# Altium schematic sheet pca20017_motors.SchDoc
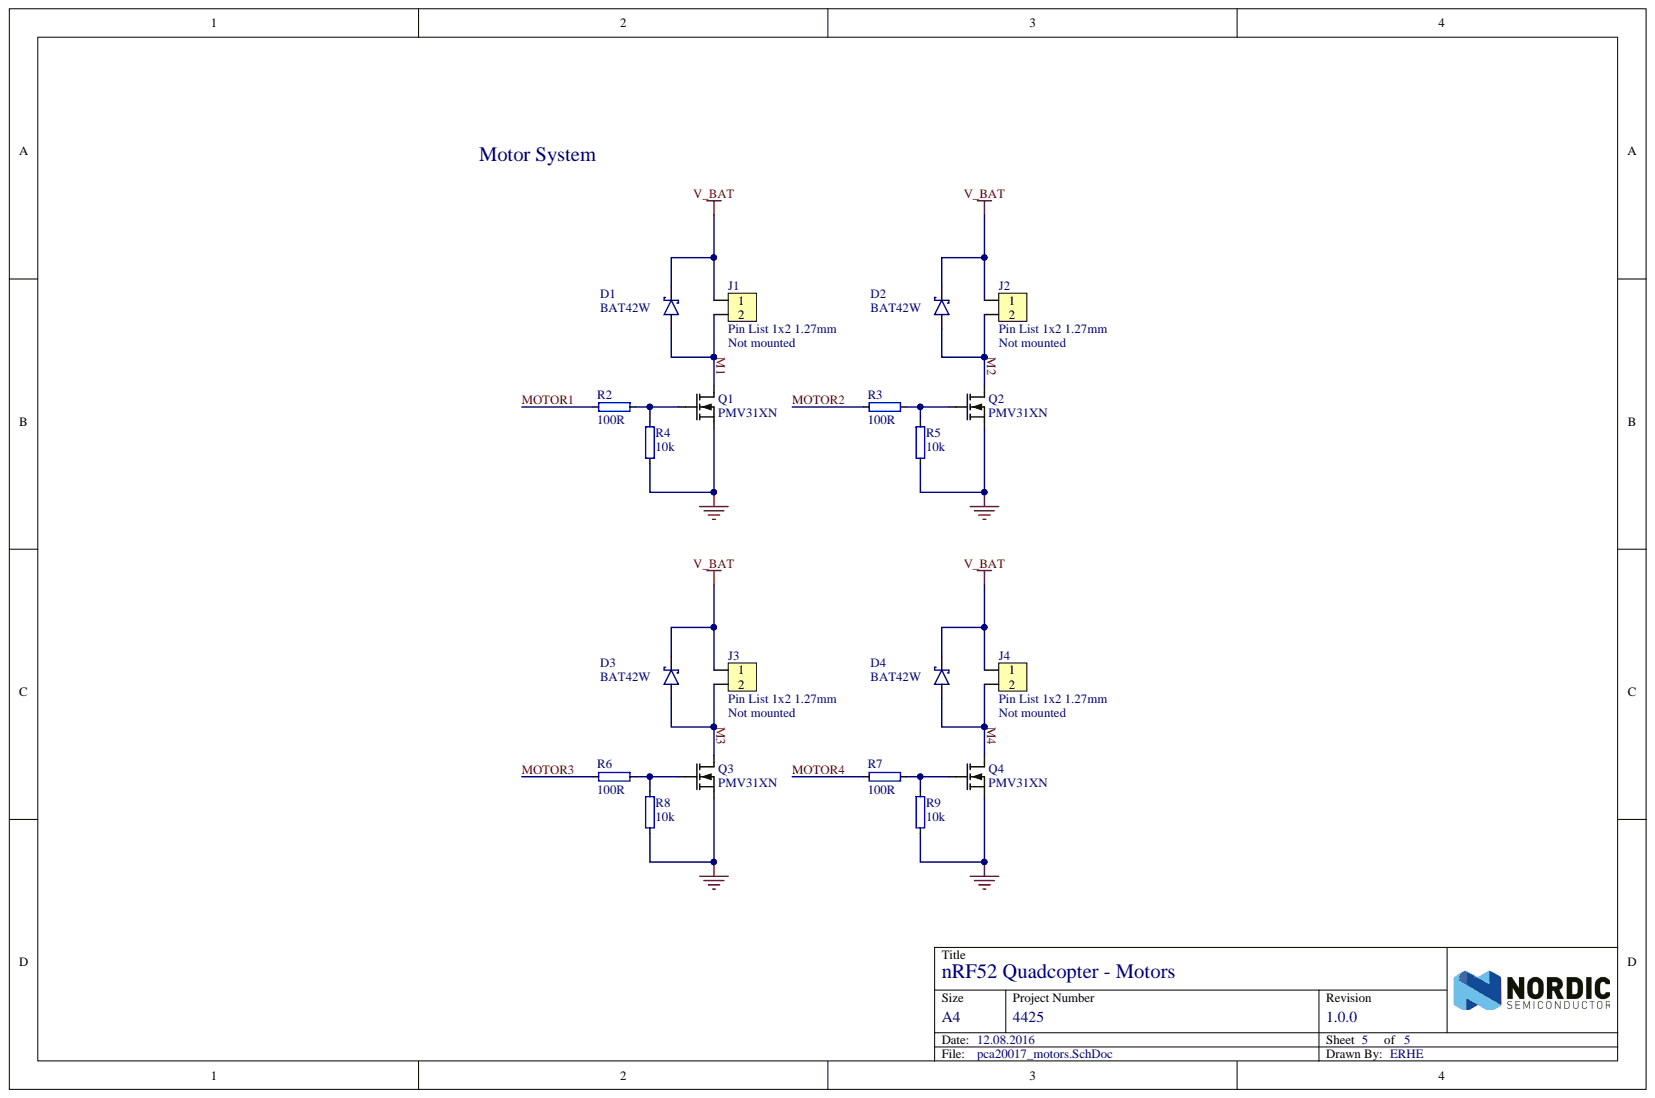
[diagram p0: pca20017_motors.SchDoc]

## Parts
D1  BAT42W  [SOD-123]
D2  BAT42W  [SOD-123]
D3  BAT42W  [SOD-123]
D4  BAT42W  [SOD-123]
J1  Pin List 1x2 1.27mm  Assembly: Not mounted  [LIST_1x2_TH_1.27mm_N.C.]
J2  Pin List 1x2 1.27mm  Assembly: Not mounted  [LIST_1x2_TH_1.27mm_N.C.]
J3  Pin List 1x2 1.27mm  Assembly: Not mounted  [LIST_1x2_TH_1.27mm_N.C.]
J4  Pin List 1x2 1.27mm  Assembly: Not mounted  [LIST_1x2_TH_1.27mm_N.C.]
Q1  PMV31XN  [SOT-23a]
Q2  PMV31XN  [SOT-23a]
Q3  PMV31XN  [SOT-23a]
Q4  PMV31XN  [SOT-23a]
R2  100R  [RESC1005X04L]
R3  100R  [RESC1005X04L]
R4  10k  [RESC1005X04L]
R5  10k  [RESC1005X04L]
R6  100R  [RESC1005X04L]
R7  100R  [RESC1005X04L]
R8  10k  [RESC1005X04L]
R9  10k  [RESC1005X04L]

## Nets
GND: Q1.2 Q2.2 Q3.2 Q4.2 R4.1 R5.1 R8.1 R9.1
V_BAT: D1.3 D2.3 D3.3 D4.3 J1.1 J2.1 J3.1 J4.1
M1: D1.1 J1.2 Q1.3
M2: D2.1 J2.2 Q2.3
M3: D3.1 J3.2 Q3.3
M4: D4.1 J4.2 Q4.3
MOTOR1: R2.1
MOTOR2: R3.1
MOTOR3: R6.1
MOTOR4: R7.1
NetQ1_1: Q1.1 R2.2 R4.2
NetQ2_1: Q2.1 R3.2 R5.2
NetQ3_1: Q3.1 R6.2 R8.2
NetQ4_1: Q4.1 R7.2 R9.2

## Library data of the parts
D1-D4 = BAT42W: Diode Schottky, Vr=30V, If=200mA, Vf=400mV, SOD-123; Manufacturer: Multicomp; Artikkelnr Noca: 10029103; Artikkelnr Simpro: N.A.; Database Table Name: Semiconductors$; Component Kind: Standard; Datasheet: http://www.farnell.com/datasheets/62636.pdf; Footprint Doc: SOD-123; LatestRevisionDate: 2011-10-10; Library Name: Nordic Discrete DB.DbLib; Manufacturer Part Number: BAT42W; Note: Diode; Part Number: BAT42W; Pin Count: 2; Published: 2011-10-10; Supplier: Farnell; Supplier part number: 162-1829; Value: BAT42W; Publisher: Nordic Semiconductor
J1-J4 = Pin List 1x2 TH, 1.27mm, N.C.: Pin List 1x2, 1.27mm (50mil), N.C.; Artikkelnr Noca: N.A.; Database Table Name: Connectors$; Artikkelnr Simpro: N.A.; Component Kind: Standard; Footprint Doc: LIST_1x2_TH_1.27mm_N.C.; LatestRevisionDate: 2015-07-03; Library Name: Nordic Passive DB.DbLib; Part Number: Pin List 1x2 TH, 1.27mm, N.C.; Pin Count: 2; Published: 2015-06-26; Value: Pin List 1x2 1.27mm; Publisher: Nordic Semiconductor
Q1-Q4 = PMV31XN: N-channel TrenchMOS FET; 2nd source part number: 1081481RL; Manufacturer: NXP; Artikkelnr Noca: N.A.; Database Table Name: Semiconductors$; Note: 20V; 2nd source Supplier: Farnell; Artikkelnr Simpro: N.A.; Component Kind: Standard; Datasheet: http://www.nxp.com/documents/data_sheet/PMV31XN.pdf; Footprint Doc: SOT23; LatestRevisionDate: 2015-06-24; Library Name: Nordic Discrete DB.DbLib; Manufacturer Part Number: PMV31XN; Part Number: PMV31XN; Published: 2015-06-24; Supplier: Digi-Key; Supplier part number: 568-2354-2-ND; Value: PMV31XN; Publisher: Nordic Semiconductor
R2 R3 R6 R7 = R0402-100: Resistor, ±1%, 0.063W; Artikkelnr Noca: 27049049; Artikkelnr Simpro: N.A.; Database Table Name: Resistors$; Note: Chip Res 1%; Value Ohm: 100; Component Kind: Standard; Footprint Doc: 0402; LatestRevisionDate: 2009-11-23; Library Name: Nordic Passive DB.DbLib; Part Number: R0402-100; Pin Count: 2; Published: 2009-05-08; Supplier: Farnell; Supplier part number: 173-8837; Value: 100R; Publisher: Nordic Semiconductor
R4 R5 R8 R9 = R0402-10k: Resistor, ±1%, 0.063W; Artikkelnr Noca: 27049097; Artikkelnr Simpro: N.A.; Database Table Name: Resistors$; Note: Chip Res 1%; Value Ohm: 10000; Component Kind: Standard; Footprint Doc: 0402; LatestRevisionDate: 2009-11-23; Library Name: Nordic Passive DB.DbLib; Part Number: R0402-10k; Pin Count: 2; Published: 2009-05-08; Supplier: Farnell; Supplier part number: 173-8864; Value: 10k; Publisher: Nordic Semiconductor
